# Altium schematic sheet Communication_MCU.SchDoc(Communication_MCU)
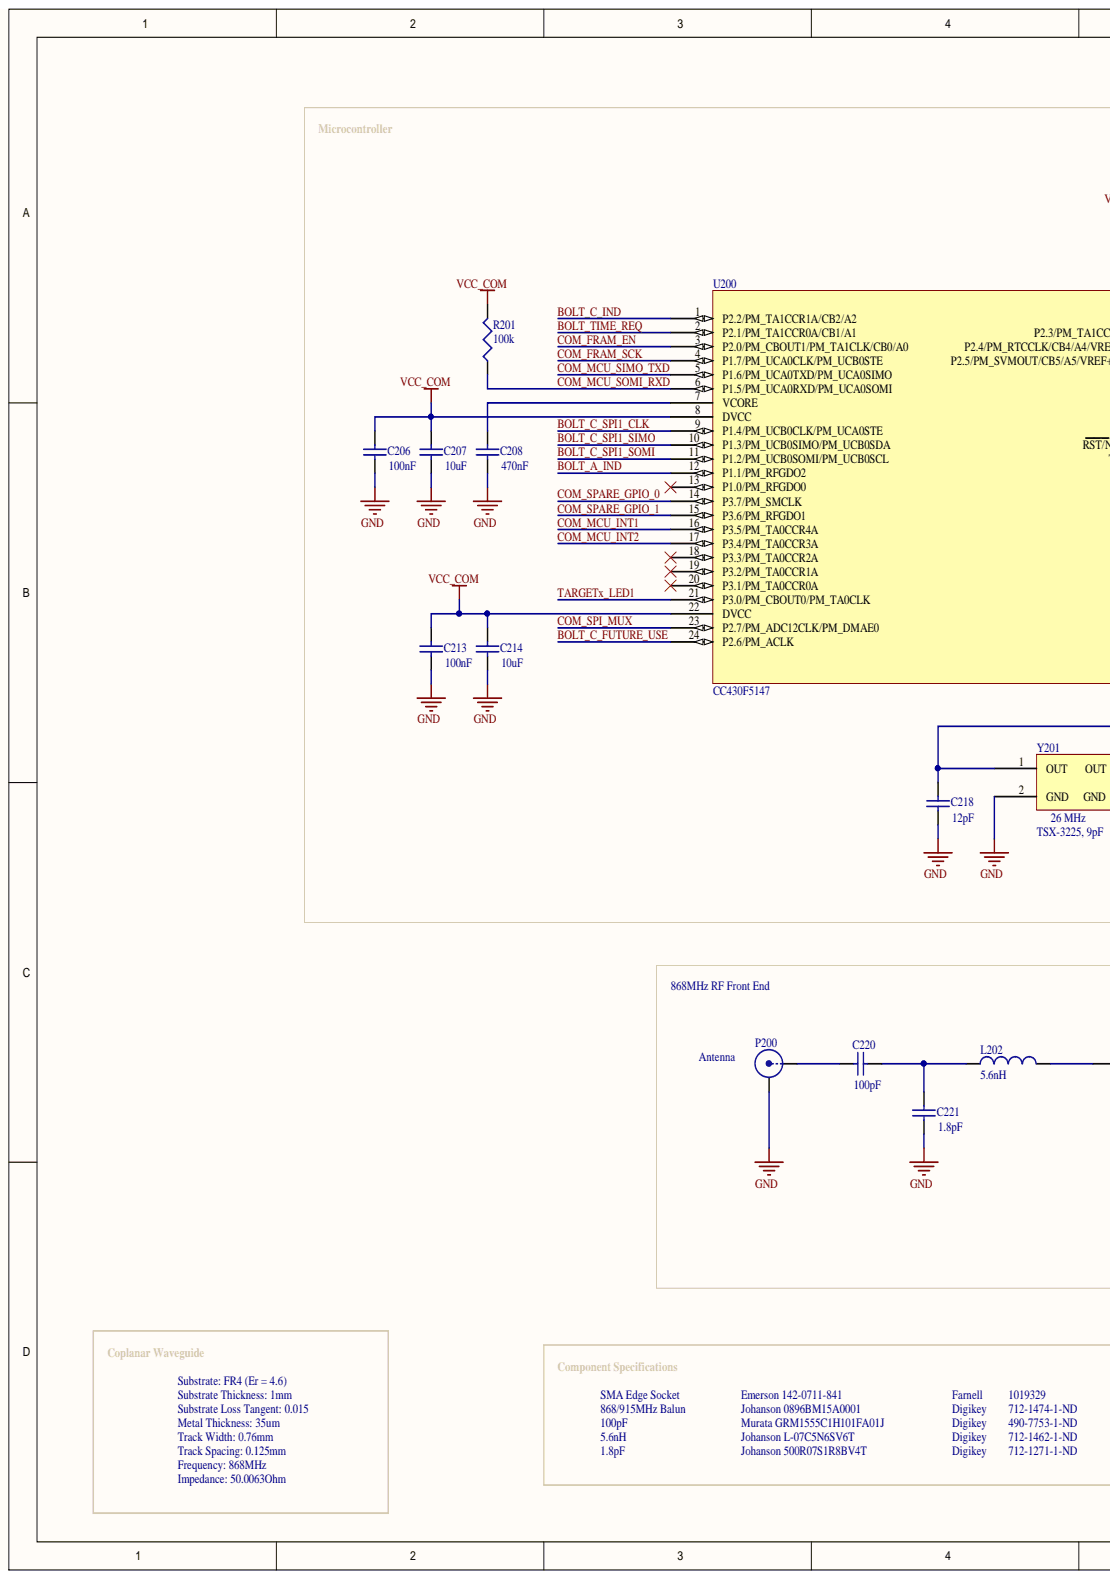
[diagram p0: Communication_MCU.SchDoc, left]
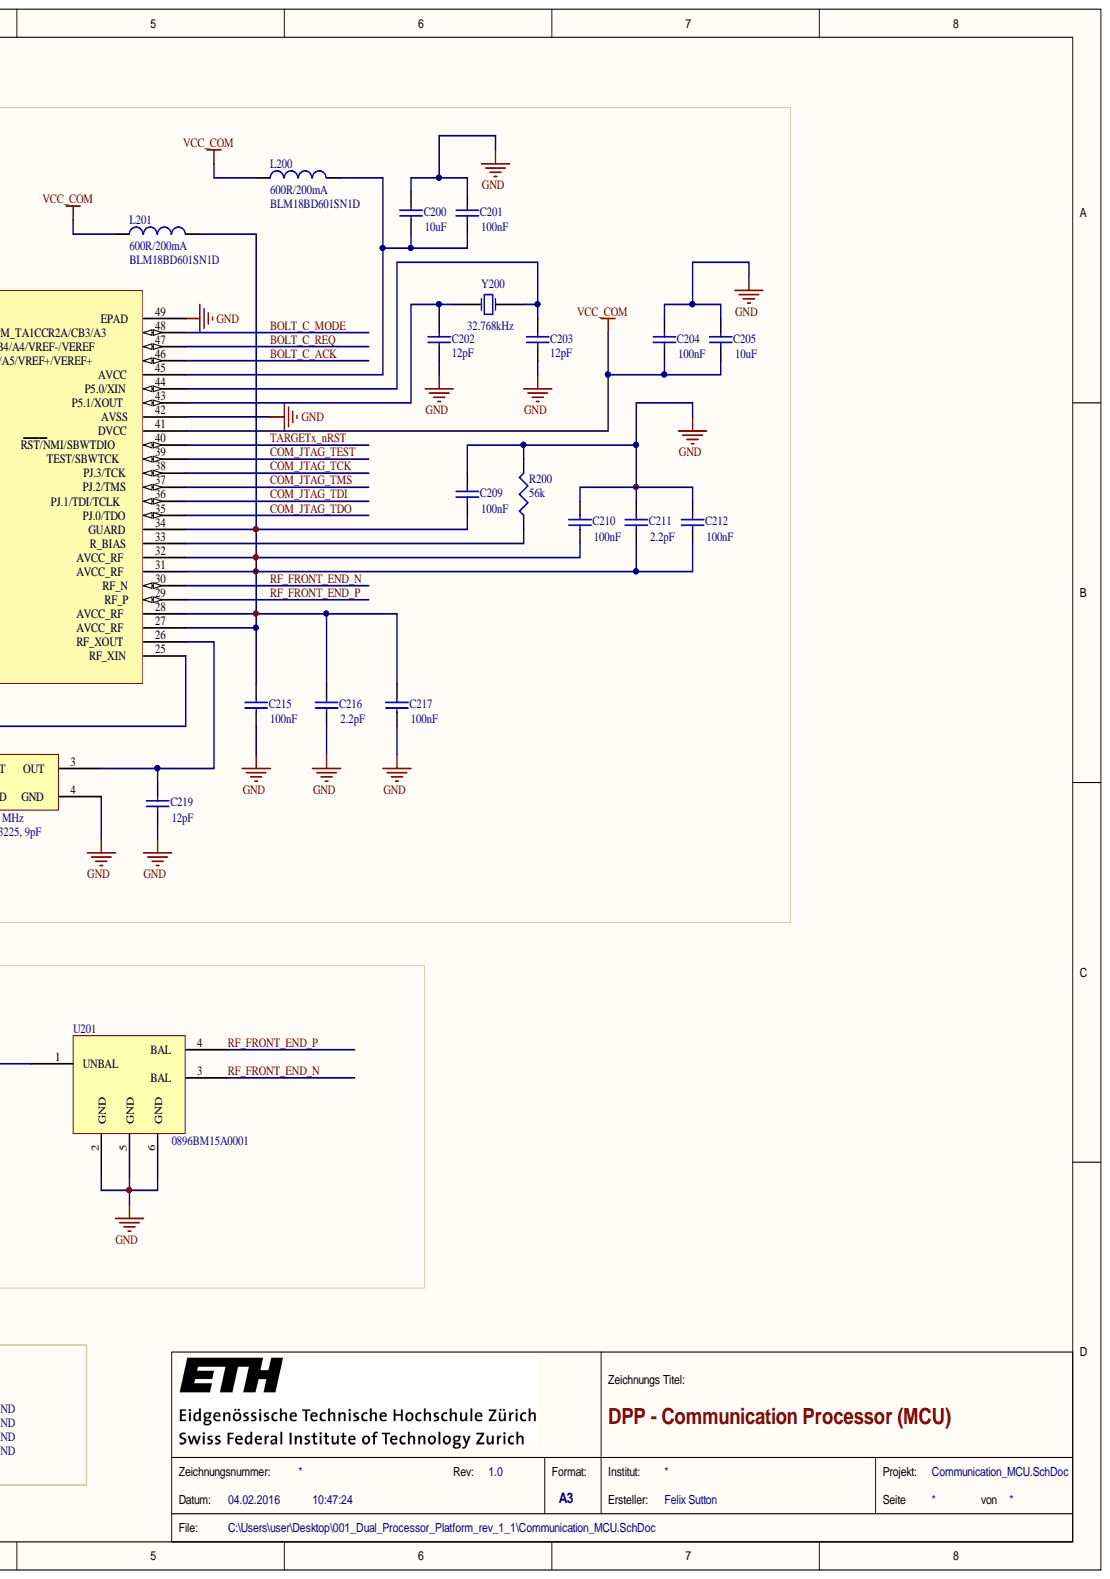
[diagram p1: Communication_MCU.SchDoc, right]

## Parts
C200  10uF  [CAPC1005N]
C201  100nF  [CAPC1005N]
C202  12pF  [CAPC1005N]
C203  12pF  [CAPC1005N]
C204  100nF  [CAPC1005N]
C205  10uF  [CAPC1005N]
C206  100nF  [CAPC1005N]
C207  10uF  [CAPC1005N]
C208  470nF  [CAPC1005N]
C209  100nF  [CAPC1005N]
C210  100nF  [CAPC1005N]
C211  2.2pF  [CAPC1005N]
C212  100nF  [CAPC1005N]
C213  100nF  [CAPC1005N]
C214  10uF  [CAPC1005N]
C215  100nF  [CAPC1005N]
C216  2.2pF  [CAPC1005N]
C217  100nF  [CAPC1005N]
C218  12pF  [CAPC1005N]
C219  12pF  [CAPC1005N]
C220  100pF  [MURATA_CAP_0402]
C221  1.8pF  [MURATA_CAP_0402]
L200  600R/200mA  value BLM18BD601SN1D  [CAPC1608N]
L201  600R/200mA  value BLM18BD601SN1D  [CAPC1608N]
L202  5.6nH  [MURATA_IND_0402]
P200  Antenna  [SMA_PCB_Small]
R200  56k  [RESC1005N]
R201  100k  [RESC1005N]
U200  CC430F5147  [RGZ_48]
U201  0896BM15A0001  [CC110L_BALUN]
Y200  32.768kHz  [FC_135]
Y201  26 MHz  [TSX_3225]

## Nets
GND: C200.2 C201.2 C202.2 C203.2 C204.1 C205.1 C206.2 C207.2 C208.2 C209.2 C210.2 C211.2 C212.2 C213.2 C214.2 C215.2 C216.2 C217.2 C218.2 C219.2 C221.2 P200.2 R200.2 U200.42(AVSS) U200.49(EPAD) U201.2(GND) U201.5(GND) U201.6(GND) Y201.2(GND) Y201.4(GND)
VCC_COM: C204.2 C205.2 C206.1 C207.1 C213.1 C214.1 L200.1 L201.1 R201.2 U200.8(DVCC) U200.22(DVCC) U200.41(DVCC)
BOLT_A_IND: U200.12(P1.1/PM_RFGDO2)
BOLT_C_ACK: U200.46
BOLT_C_FUTURE_USE: U200.24(P2.6/PM_ACLK)
BOLT_C_IND: U200.1(P2.2/PM_TA1CCR1A/CB2/A2)
BOLT_C_MODE: U200.48
BOLT_C_REQ: U200.47
BOLT_C_SPI1_CLK: U200.9(P1.4/PM_UCB0CLK/PM_UCA0STE)
BOLT_C_SPI1_SIMO: U200.10(P1.3/PM_UCB0SIMO/PM_UCB0SDA)
BOLT_C_SPI1_SOMI: U200.11(P1.2/PM_UCB0SOMI/PM_UCB0SCL)
BOLT_TIME_REQ: U200.2(P2.1/PM_TA1CCR0A/CB1/A1)
COM_FRAM_EN: U200.3(P2.0/PM_CBOUT1/PM_TA1CLK/CB0/A0)
COM_FRAM_SCK: U200.4(P1.7/PM_UCA0CLK/PM_UCB0STE)
COM_JTAG_TCK: U200.38(PJ.3/TCK)
COM_JTAG_TDI: U200.36(PJ.1/TDI/TCLK)
COM_JTAG_TDO: U200.35(PJ.0/TDO)
COM_JTAG_TEST: U200.39(TEST/SBWTCK)
COM_JTAG_TMS: U200.37(PJ.2/TMS)
COM_MCU_INT1: U200.16(P3.5/PM_TA0CCR4A)
COM_MCU_INT2: U200.17(P3.4/PM_TA0CCR3A)
COM_MCU_SIMO_TXD: U200.5(P1.6/PM_UCA0TXD/PM_UCA0SIMO)
COM_MCU_SOMI_RXD: R201.1 U200.6(P1.5/PM_UCA0RXD/PM_UCA0SOMI)
COM_SPARE_GPIO_0: U200.14(P3.7/PM_SMCLK)
COM_SPARE_GPIO_1: U200.15(P3.6/PM_RFGDO1)
COM_SPI_MUX: U200.23(P2.7/PM_ADC12CLK/PM_DMAE0)
RF_FRONT_END_N: U200.30(RF_N) U201.3(BAL)
RF_FRONT_END_P: U200.29(RF_P) U201.4(BAL)
TARGETX_LED1: U200.21(P3.0/PM_CBOUT0/PM_TA0CLK)
TARGETX_NRST: U200.40
NetC200_1: C200.1 C201.1 L200.2 U200.45(AVCC)
NetC202_1: C202.1 U200.43(P5.1/XOUT) Y200.1
NetC203_1: C203.1 U200.44(P5.0/XIN) Y200.2
NetC208_1: C208.1 U200.7(VCORE)
NetC209_1: C209.1 C210.1 C211.1 C212.1 C215.1 C216.1 C217.1 L201.2 U200.27(AVCC_RF) U200.28(AVCC_RF) U200.31(AVCC_RF) U200.32(AVCC_RF) U200.34(GUARD)
NetC218_1: C218.1 U200.25(RF_XIN) Y201.1(OUT)
NetC219_1: C219.1 U200.26(RF_XOUT) Y201.3(OUT)
NetC220_1: C220.1 P200.1
NetC220_2: C220.2 C221.1 L202.1
NetL202_2: L202.2 U201.1(UNBAL)
NetR200_1: R200.1 U200.33(R_BIAS)

Not connected: U200 13(P1.0/PM_RFGDO0) 18(P3.3/PM_TA0CCR2A) 19(P3.2/PM_TA0CCR1A) 20(P3.1/PM_TA0CCR0A)

## Library data of the parts
C200 C201 C204-C221 = Cap: Capacitor, Ceramic; Component Kind: Standard; LatestRevisionDate: 17-Jul-2002; LatestRevisionNote: Re-released for DXP Platform.; PackageDescription: Radial Cap, Thru-Hole; 2 Leads; 0.3 in Pin Spacing; PackageReference: RAD-0.3; Published: 8-Jun-2000; Signal Integrity: Cap; Simulation: CAP; Library Name: PermaSense.SchLib; Publisher: Altium Limited
C202 C203 = Cap: Capacitor; Component Kind: Standard; LatestRevisionDate: 17-Jul-2002; LatestRevisionNote: Re-released for DXP Platform.; Library Name: Miscellaneous Devices.IntLib; PackageReference: RAD-0.3; Published: 8-Jun-2000; Publisher: Altium Limited
L200 L201 = Inductor: Ferrite Bead; Code_IEC: 1005; Code_JEITA: 0402; Component Kind: Standard; LatestRevisionDate: 29-May-2009; LatestRevisionNote: IPC-7351 Footprint Added.; Library Name: Miscellaneous Devices.IntLib; PackageDescription: Chip Inductor; PackageReference: 0402-A; Published: 8-Jun-2000; Signal Integrity: Inductor; Simulation: INDUCTOR; Publisher: Altium Limited
L202 = Inductor: Code_IEC: 1005; Code_JEITA: 0402; Component Kind: Standard; Description: Inductor; LatestRevisionDate: 29-May-2009; LatestRevisionNote: IPC-7351 Footprint Added.; Library Name: Miscellaneous Devices.IntLib; PackageDescription: Chip Inductor; PackageReference: 0402-A; Published: 8-Jun-2000; Signal Integrity: Inductor; Simulation: INDUCTOR; Publisher: Altium Limited
P200 = SMB: SMB Straight Connector; Component Kind: Standard; LatestRevisionDate: 17-Jul-2002; LatestRevisionNote: Re-released for DXP Platform.; Library Name: Miscellaneous Connectors.IntLib; Publisher: Altium Limited
R200 R201 = Res3: Resistor; Component Kind: Standard; LatestRevisionDate: 29-May-2009; LatestRevisionNote: IPC-7351 Footprint Added.; Library Name: Miscellaneous Devices.IntLib; PackageDescription: Chip Resistor; PackageReference: J1-0603; Published: 8-Jun-2000; Publisher: Altium Limited
U200 = CC430F5137: TI CC430 MCU+Radio; Component Kind: Standard; Library Name: Felix_Component_Library.SchLib
U201 = 0433BM15A0001: Johanson Technology 433MHz Balun for TI CC110L; Component Kind: Standard; Library Name: Felix_Misc_Components.SchLib
Y200 = FC-135: Crystal Oscillator, 32.768kHz, 12.5pF; Component Kind: Standard; Supplier 1: Digi-Key; Supplier 2: Digi-Key; Supplier 3: Farnell; Supplier Part Number 1: SE2413DKR-ND; Supplier Part Number 2: SE2413CT-ND; Supplier Part Number 3: 1278036; Temperature: -40 +85; Library Name: PermaSense.SchLib
Y201 = TSX-3225: Oscillator, Epson, 26MHz for CC430; Component Kind: Standard; Library Name: PermaSense.SchLib
